# Altium schematic sheet Leds.SchDoc
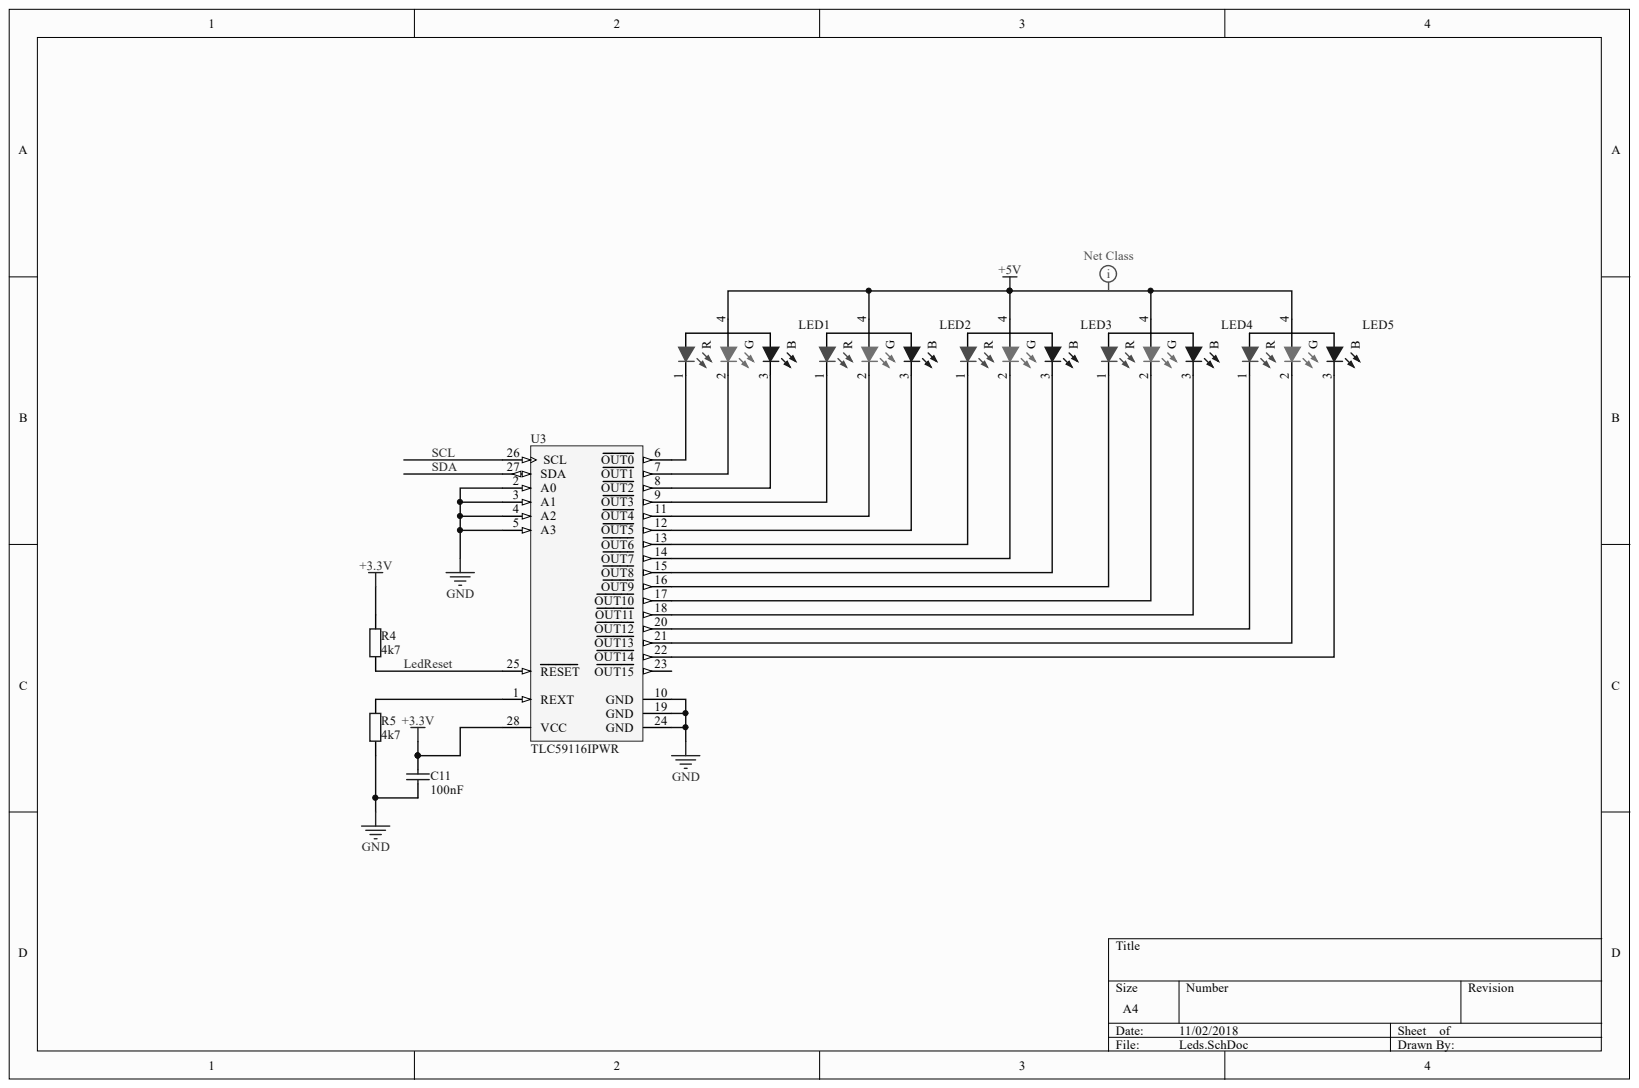
[diagram p0: Leds.SchDoc]

## Parts
C11  100nF  [PCB-14j6yrx10pagyq47cjuv-1]
LED1  Avago Technologies ASMB-MTB1-0A3A2  [PCB-15261128-1]  (value not drawn)
LED2  Avago Technologies ASMB-MTB1-0A3A2  [PCB-15261128-1]  (value not drawn)
LED3  Avago Technologies ASMB-MTB1-0A3A2  [PCB-15261128-1]  (value not drawn)
LED4  Avago Technologies ASMB-MTB1-0A3A2  [PCB-15261128-1]  (value not drawn)
LED5  Avago Technologies ASMB-MTB1-0A3A2  [PCB-15261128-1]  (value not drawn)
R4  4k7  [PCB-1vayfl3026fycqkmw7iz-1]
R5  4k7  [PCB-1vayfl3026fycqkmw7iz-1]
U3  TLC59116IPWR  [TI-PW28_L]

## Nets
+3.3V: C11.2 R4.2 U3.28(VCC)
+5V: LED1.4 LED2.4 LED3.4 LED4.4 LED5.4
GND: C11.1 R5.1 U3.2(A0) U3.3(A1) U3.4(A2) U3.5(A3) U3.10(GND) U3.19(GND) U3.24(GND)
LEDRESET: R4.1 U3.25(RESET)
SCL: U3.26(SCL)
SDA: U3.27(SDA)
NetLED1_1: LED1.1 U3.6(OUT0)
NetLED1_2: LED1.2 U3.7(OUT1)
NetLED1_3: LED1.3 U3.8(OUT2)
NetLED2_1: LED2.1 U3.9(OUT3)
NetLED2_2: LED2.2 U3.11(OUT4)
NetLED2_3: LED2.3 U3.12(OUT5)
NetLED3_1: LED3.1 U3.13(OUT6)
NetLED3_2: LED3.2 U3.14(OUT7)
NetLED3_3: LED3.3 U3.15(OUT8)
NetLED4_1: LED4.1 U3.16(OUT9)
NetLED4_2: LED4.2 U3.17(OUT10)
NetLED4_3: LED4.3 U3.18(OUT11)
NetLED5_1: LED5.1 U3.20(OUT12)
NetLED5_2: LED5.2 U3.21(OUT13)
NetLED5_3: LED5.3 U3.22(OUT14)
NetR5_2: R5.2 U3.1(REXT)

Not connected: U3 23(OUT15)

## Library data of the parts
C11 = CMP-cab80115e1b0157f-1: 0603 1 uF 10 V ±10 % Tol. X5R Surface Mount Multilayer Ceramic Capacitor; Capacitance Tolerance: ±10%; Lead-Free Status: Lead Free; Voltage Rating (DC): 10.0 V; Case/Package: 0603; Component Kind: Standard; Dielectric Characteristic: X5R; Library Name: CommunityVault; Mounting Style: Surface Mount; OctopartID: cab80115e1b0157f; Octopart URL: http://octopart.com/0603x105k100ct-walsin+technologies-44502638; Packaging: Cut Tape (CT); RoHS: Compliant
LED1-LED5 = CMP-15261128-5: LED Tri-Color Blue/Green/Red 465/522/634nm Chip LED 4-Pin PLCC T/R; Viewing Angle: 115 °; Component Kind: Standard; Library Name: CommunityVault; Lifecycle Status: Active; Number of Pins: 4; OctopartID: 84484c90388f5b8f; Octopart Url: https://octopart.com/asmb-mtb1-0a3a2-avago-30579105; Packaging: Cut Tape (CT); REACH SVHC Compliance: No SVHC; RoHS: Compliant
R4 R5 = CMP-91545b5de2944e93-1: Surface Mount Thick Film Resistor, MCWR Series, 4.7 kohm, 100 mW, - 1%, 50 V; Manufacturer Part Number: MCWR06X4701FTL; Case/Package (SI): 1608; Power Rating: 100 mW; Resistance: 4.70 kΩ; Case/Package: 0603, 1608; Component Kind: Standard; Library Name: CommunityVault; OctopartID: 91545b5de2944e93; Octopart URL: http://octopart.com/mcwr06x4701ftl-multicomp-50550438; Packaging: Tape & Reel (TR), Cut Tape (CT); REACH SVHC Compliance: No SVHC; Resistance Tolerance: ±1%; RoHS: Compliant
U3 = TLC59116IPWR: 16-Bit Fast-Mode Plus (FM+) I2C Bus Constant-Current LED Sink Driver, 3 to 5.5 V, -40 to 85 degC, 28-pin SOP (PW28), Green (RoHS & no Sb/Br); Lead-Free Status: Lead Free; Number of Outputs: 16; Output Current: 0.12 A; Output Voltage: 17.0 V; Component Kind: Standard; Library Name: Octopart library; Lifecycle Status: Active; Mounting Style: Surface Mount; Number of Pins: 28; OctopartID: 667eaf0d8e01b9b9; Octopart Url: https://octopart.com/tlc59116ipwr-texas+instruments-7982361; Packaging: Cut Tape (CT); REACH SVHC Compliance: No SVHC; RoHS: Compliant
